# Altium schematic sheet 2228_Emetteur_Alimentation.SchDoc
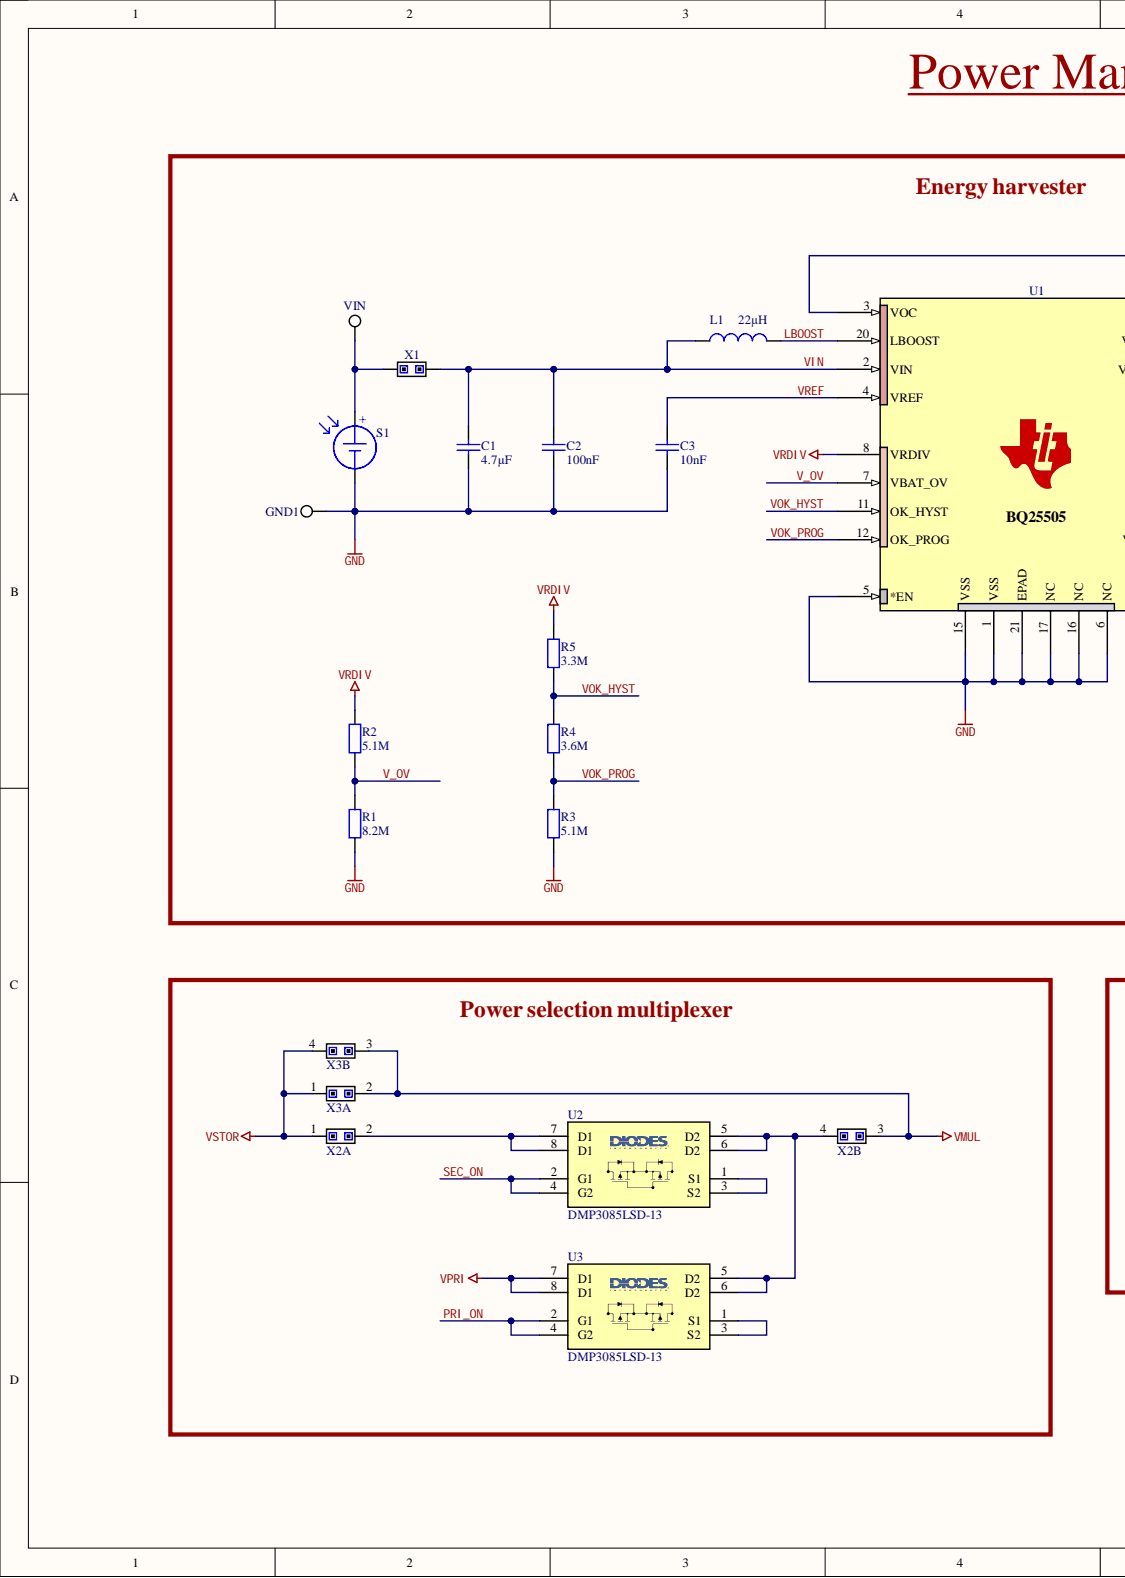
[diagram p0: 2228_Emetteur_Alimentation.SchDoc, left]
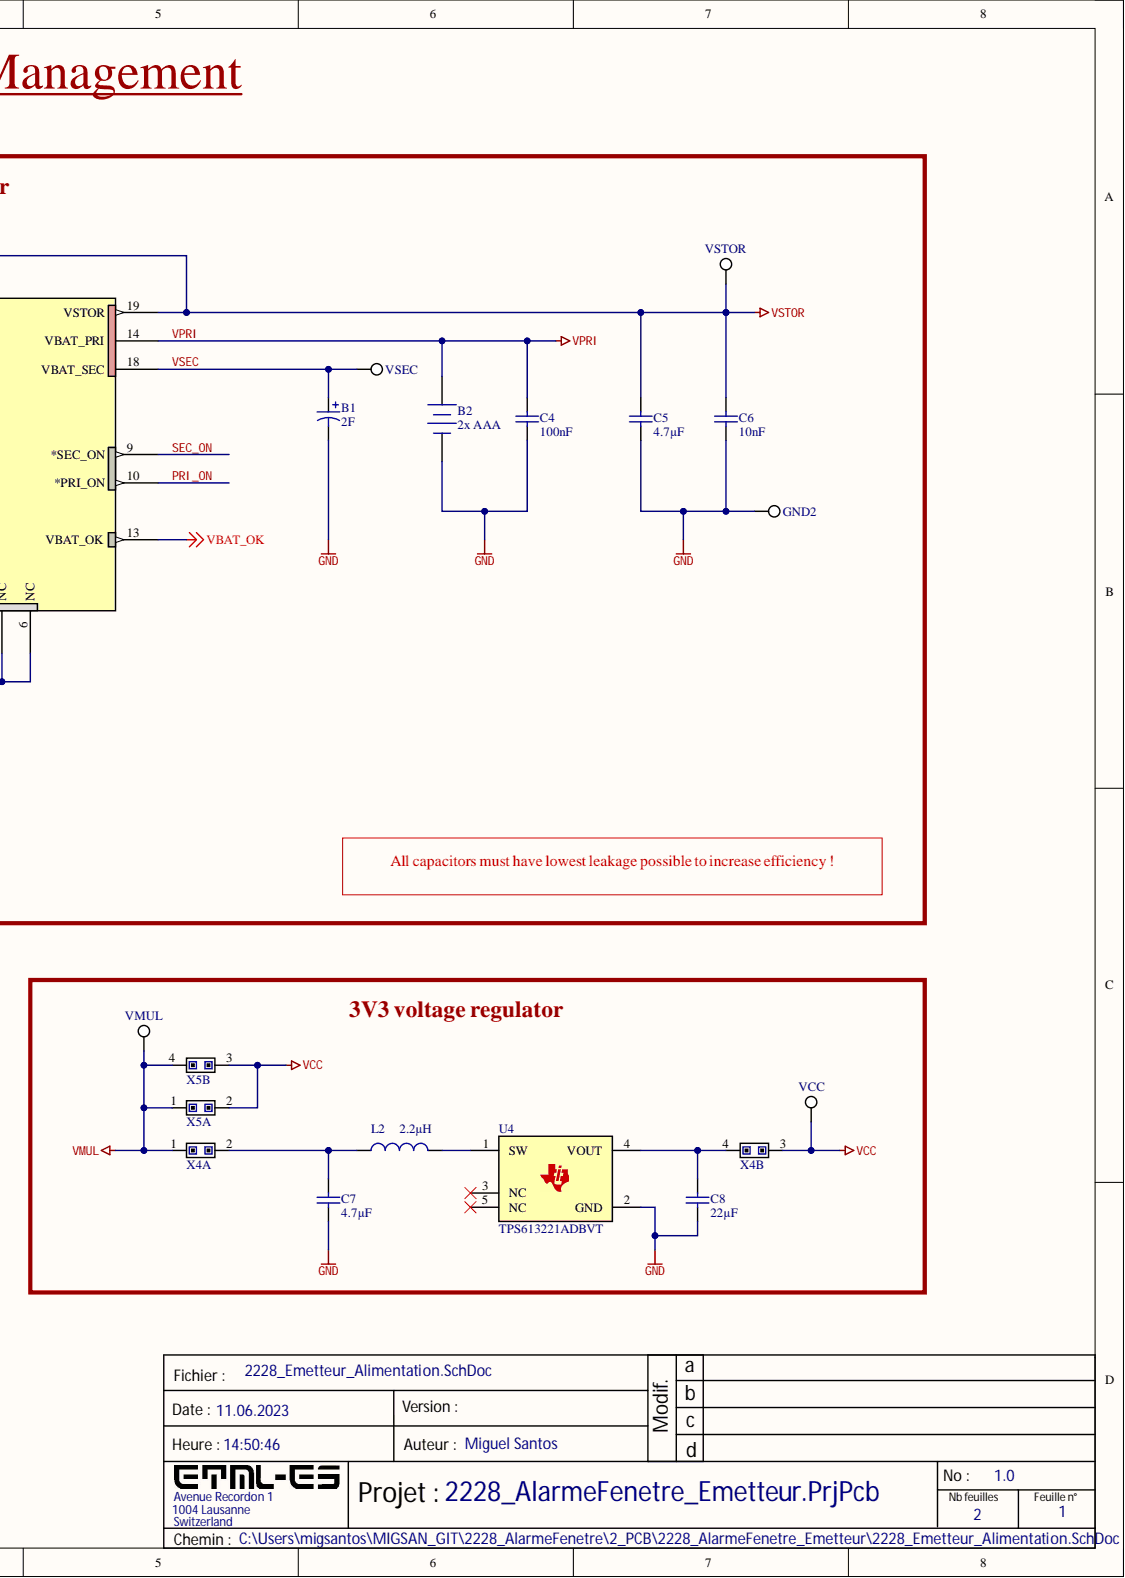
[diagram p1: 2228_Emetteur_Alimentation.SchDoc, right]

## Parts
B1  value 2F  [SuperCap]  (hidden comment: SUPERCAP)
B2  2x AAA  [WE_2PINS]
C1  value 4.7µF  [CAP_0805]  (hidden comment: CAP_SMD)
C2  value 100nF  [CAP_0805]  (hidden comment: CAP_SMD)
C3  value 10nF  [CAP_0805]  (hidden comment: CAP_SMD)
C4  value 100nF  [CAP_0805]  (hidden comment: CAP_SMD)
C5  value 4.7µF  [CAP_0805]  (hidden comment: CAP_SMD)
C6  value 10nF  [CAP_0805]  (hidden comment: CAP_SMD)
C7  value 4.7µF  [CAP_0805]  (hidden comment: CAP_SMD)
C8  value 22µF  [CAP_0805]  (hidden comment: CAP_SMD)
GND1  5019  [KSTN-5019_V]  (value not drawn)
GND2  5019  [KSTN-5019_V]  (value not drawn)
L1  value 22µH  [WE-LQFS_4828]  (hidden comment: IND_SMD)
L2  value 2.2µH  [IND_0805]  (hidden comment: IND_SMD)
R1  value 8.2M  [RES_0805]  (hidden comment: RES_SMD)
R2  value 5.1M  [RES_0805]  (hidden comment: RES_SMD)
R3  value 5.1M  [RES_0805]  (hidden comment: RES_SMD)
R4  value 3.6M  [RES_0805]  (hidden comment: RES_SMD)
R5  value 3.3M  [RES_0805]  (hidden comment: RES_SMD)
S1  Solar_Cell  [KXOB25-05X3F-TR]  (value not drawn)
U1  BQ25505  [QFN_25505]
U2  DMP3085LSD-13  [SO-8]
U3  DMP3085LSD-13  [SO-8]
U4  TPS613221ADBVT  [SOT95P280X145-5N]
VCC  5019  [KSTN-5019_V]  (value not drawn)
VIN  5019  [KSTN-5019_V]  (value not drawn)
VMUL  5019  [KSTN-5019_V]  (value not drawn)
VSEC  5019  [KSTN-5019_V]  (value not drawn)
VSTOR  5019  [KSTN-5019_V]  (value not drawn)
X1  2PIN_HEADER  [HEADER_2PINS]  (value not drawn)
X2  2X2PIN_HEADER  [2x2_HEADER]  (value not drawn)  (parts A,B)
X3  2X2PIN_HEADER  [2x2_HEADER]  (value not drawn)  (parts A,B)
X4  2X2PIN_HEADER  [2x2_HEADER]  (value not drawn)  (parts A,B)
X5  2X2PIN_HEADER  [2x2_HEADER]  (value not drawn)  (parts A,B)

## Nets
GND: B1.2 B2.2 C1.1 C2.1 C3.2 C4.1 C5.1 C6.1 C7.1 C8.1 GND1.1 GND2.1 R1.2 R3.2 S1.2 U1.1(VSS) U1.5(*EN) U1.6(NC) U1.15(VSS) U1.16(NC) U1.17(NC) U1.21(EPAD) U4.2(GND)
VBAT_OK: U1.13(VBAT_OK)
VCC: VCC.1 X4.3 X5.2 X5.3
VMUL: VMUL.1 X2.3 X3.2 X3.3 X4.1 X5.1 X5.4
VRDIV: R2.1 R5.1 U1.8(VRDIV)
VSTOR: C5.2 C6.2 U1.3(VOC) U1.19(VSTOR) VSTOR.1 X2.1 X3.1 X3.4
LBOOST: L1.2 U1.20(LBOOST)
PRI_ON: U1.10(*PRI_ON) U3.2(G1) U3.4(G2)
SEC_ON: U1.9(*SEC_ON) U2.2(G1) U2.4(G2)
V_OV: R1.1 R2.2 U1.7(VBAT_OV)
VIN: C1.2 C2.2 L1.1 U1.2(VIN) X1.2
VOK_HYST: R4.1 R5.2 U1.11(OK_HYST)
VOK_PROG: R3.1 R4.2 U1.12(OK_PROG)
VPRI: B2.1 C4.2 U1.14(VBAT_PRI) U3.7(D1) U3.8(D1)
VREF: C3.1 U1.4(VREF)
VSEC: B1.1 U1.18(VBAT_SEC) VSEC.1
NetC7_2: C7.2 L2.1 X4.2
NetC8_2: C8.2 U4.4(VOUT) X4.4
NetL2_2: L2.2 U4.1(SW)
NetS1_1: S1.1 VIN.1 X1.1
NetU2_1: U2.1(S1) U2.3(S2)
NetU2_5: U2.5(D2) U2.6(D2) U3.5(D2) U3.6(D2) X2.4
NetU2_7: U2.7(D1) U2.8(D1) X2.2
NetU3_1: U3.1(S1) U3.3(S2)

Not connected: U4 3(NC) 5(NC)

## Library data of the parts
B1 = SUPERCAP: Polarized SuperCapacitor (Radial); Component Kind: Standard; Supplier 1: Digi-Key; Supplier Part Number 1: 338-DSF205Q3R0-ND; Library Name: 2228_AlarmeFenetre_Symbols.SchLib
B2 = Battery: Multicell Battery; Component Kind: Standard; Library Name: 2228_AlarmeFenetre_Symbols.SchLib
C1-C8 = CAP_SMD: Capacitor; Component Kind: Standard; Library Name: 2228_AlarmeFenetre_Symbols.SchLib
GND1 GND2 VCC VIN VMUL VSEC VSTOR = CMP-1672-00008-1: Test Point, 1 Position SMD, RoHS, Tape and Reel; Component Kind: Standard; ComponentLink1Description: Manufacturer URL; ComponentLink1URL: http://www.keyelco.com/; ComponentLink2Description: Datasheet; ComponentLink2URL: http://www.keyelco.com/product-pdf.cfm?p=1357; Contact Plating: Silver; DatasheetVersion: 03/2014; Library Name: Altium Content Vault; Mount: Surface Mount; Mounting Technology: Surface Mount; Package Quantity: 1000; PackageDescription: 1-Pin Surface Mount Device, Body 3.81 x 2.03 mm; PackageReference: 5019; Packaging: Tape and Reel; Pins: 1; RoHSCompliant: True
L1 L2 = IND_SMD: Inductor SMD; Component Kind: Standard; Supplier 1: Digi-Key; Library Name: 2228_AlarmeFenetre_Symbols.SchLib
  L1: Supplier Part Number 1: 732-10821-1-ND
  L2: Supplier Part Number 1: 587-2043-1-ND
S1 = Solar_Cell: Anysolar KXOB25-05X3F; Component Kind: Standard; Manufacturer: Anysolar; Manufacturer_PN: KXOB25-05X3F-TR; Supplier 1: Digi-Key; Supplier Part Number 1: 2994-KXOB25-05X3TF-TRCT-ND; Library Name: 2228_AlarmeFenetre_Symbols.SchLib
U1 = BQ25505RGRR: Low power energy harvester; Manufacturer: Texas Instruments; Component Kind: Standard; Manufacturer_Number: BQ25505RGRR; Supplier 1: Digi-Key; Supplier Part Number 1: 296-37078-1-ND; Library Name: 2228_AlarmeFenetre_Symbols.SchLib
U2 U3 = DMP3085LSD-13: Manufacturer: Diodes Inc; Component Kind: Standard; Manufacturer_Number: DMP3085LSD-13; Supplier 1: Digi-Key; Supplier Part Number 1: DMP3085LSD-13DICT-ND; Library Name: 2228_AlarmeFenetre_Symbols.SchLib
U4 = TPS613221ADBVT: Manufacturer: Texas Instruments; Component Kind: Standard; Manufacturer_Number: TPS613221ADBVT; Library Name: 2228_AlarmeFenetre_Symbols.SchLib
R1-R5 = RES_SMD: Component Kind: Standard; Library Name: 2228_AlarmeFenetre_Symbols.SchLib
  R1: Supplier 1: Digi-Key; Supplier Part Number 1: 541-7.87MHCT-ND
X1 = 2PIN_HEADER: Component Kind: Standard; Library Name: 2228_AlarmeFenetre_Symbols.SchLib
X2-X5 = 2X2PIN_HEADER: Component Kind: Standard; Library Name: 2228_AlarmeFenetre_Symbols.SchLib
